# Altium schematic sheet Misc.SchDoc(Misc)
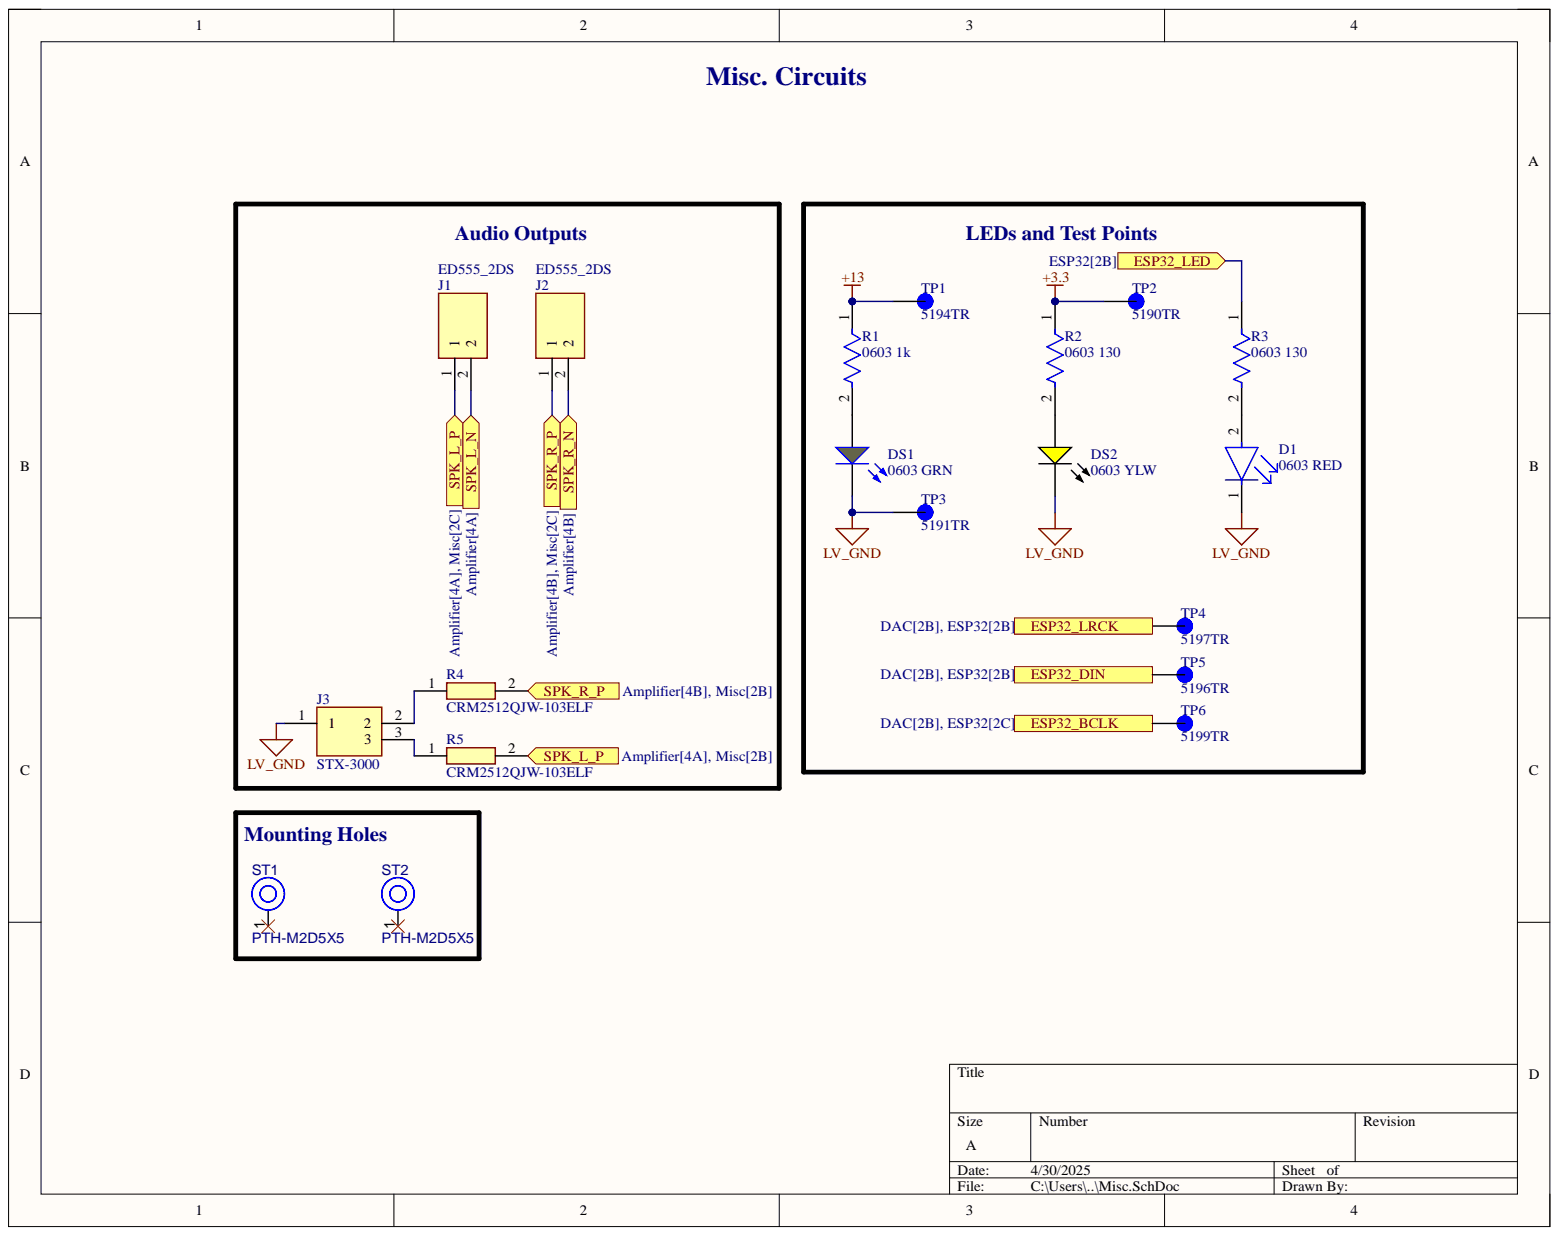
[diagram p0: Misc.SchDoc]

## Parts
D1  0603 RED  Case Code (Imperial): 0603  [FP-SML-LX0603IW-TR-MFG]
DS1  0603 GRN  [LUMX-SML-LX0603_V]
DS2  0603 YLW  [LUMX-SML-LX0603_V]
J1  ED555_2DS  [SHDR2W90P0X350_1X2_700X650X820P]
J2  ED555_2DS  [SHDR2W90P0X350_1X2_700X650X820P]
J3  STX-3000  [STX3000]
R1  0603 1k  Case Code (Imperial): 0603  [FP-CRCW0603-e3-MFG]
R2  0603 130  Case Code (Imperial): 0603  [FP-CRCW0603-e3-MFG]
R3  0603 130  Case Code (Imperial): 0603  [FP-CRCW0603-e3-MFG]
R4  CRM2512QJW-103ELF  [RESC6331X80N]
R5  CRM2512QJW-103ELF  [RESC6331X80N]
ST1  PTH-M2D5X5  [PTH-M2D5X5]
ST2  PTH-M2D5X5  [PTH-M2D5X5]
TP1  5194TR  [KEYSTONE_5194TR]
TP2  5190TR  [KEYSTONE_5190TR]
TP3  5191TR  [KEYSTONE_5191TR]
TP4  5197TR  [KEYSTONE_5197TR]
TP5  5196TR  [KEYSTONE_5196TR]
TP6  5199TR  [KEYSTONE_5199TR]

## Ports
ESP32_BCLK, ESP32_DIN, ESP32_LED, ESP32_LRCK, SPK_L_N, SPK_L_P, SPK_R_N, SPK_R_P

## Nets
+3.3: R2.1 TP2.TP
+13: R1.1 TP1.TP
LV_GND: D1.1 DS1.2 DS2.2 J3.1 TP3.TP
ESP32_BCLK: TP6.TP ; port ESP32_BCLK
ESP32_DIN: TP5.TP ; port ESP32_DIN
ESP32_LED: R3.1 ; port ESP32_LED
ESP32_LRCK: TP4.TP ; port ESP32_LRCK
SPK_L_N: J1.2 ; port SPK_L_N
SPK_L_P: J1.1 R5.2 ; port SPK_L_P
SPK_R_N: J2.2 ; port SPK_R_N
SPK_R_P: J2.1 R4.2 ; port SPK_R_P
NetD1_2: D1.2 R3.2
NetDS1_1: DS1.1 R1.2
NetDS2_1: DS2.1 R2.2
NetJ3_2: J3.2 R4.1
NetJ3_3: J3.3 R5.1

Not connected: ST1 1; ST2 1

## Library data of the parts
D1 = CMP-57192-000012-1: LED RED DIFFUSED SMD; Approvals: UL; Dominant Wavelength: 635nm; Forward Current: 20mA; Forward Voltage: 2V; Lens Color: White; Luminous Intensity: 14mcd; Number of LEDs: 1; Power Dissipation: 50mW; Viewing Angle: 160°; Case Code (Metric): 1608; Case/Package: 0603; Color: Red; Component Kind: Standard; Diameter: 0.061inch; Height: 0.6mm; Illumination Color: Red; Lead Free: Lead Free; Length: 1.6mm; Lens Style: Diffused; Lens Transparency: Diffused; Library Name: Altium Content Vault; Max Operating Temperature: 85°C; Min Operating Temperature: -25°C; Mount: Surface Mount; Number of Pins: 2; Package Quantity: 1; Packaging: Tape and Reel; RoHS Compliant: Yes; Test Current: 20mA; Wavelength: 635nm; Width: 0.8mm
DS1 = CMP-2000-07120-1: LED Green Diode, 18 mcd, 50 mW, -25 to 85 degC, 2-Pin SMD, RoHS, Tape and Reel; Manufacturer: Lumex; Part No: SML-LX0603GW-TR; COO: CN; Intensity: 18mcd; LED Material: GaP; Lens Appearance: ColorlessDiffused; Number of LEDs: 1; Peak Wavelength: 565nm; Pin_Count: 2; Reverse Voltage: 5(Min)V; Type: Uni-Color; Viewing Angle: 160; Color: Green; Component Kind: Standard; Datasheet URL: http://www.lumex.com/content/files/ProductAttachment/SML-LX0603GW-TR.pdf; Datasheet Version: Rev. G, 09/2013; Dimension: 1.6x0.8x0.6mm; Dominant Wavelength: N/A; ECCN: EAR99; Family: SML-LX0603GW; HTS: 8541402000; Lens Dimensions: 1x0.8x0.3mm; Library Name: Altium Content Vault; Manufacturer URL: http://www.lumexinstruments.com/; Mounting: SurfaceMount; Mounting Technology: SurfaceMount; Operating Temperature: -25to85C; Package Description: 2-Pin Surface Mount Device, Body 1.6 x 0.8 mm; Package Reference: SML-LX00603; Packaging: TapeandReel; RoHS: Yes; Supplier: Lumex; Supplier Standard Pack: 4,000; Supplier_Package: SMD
DS2 = CMP-2000-07121-1: Yellow LED, Milky White Diffused Lens, 2.1 V, 14 mcd, -25 to 85 degC, 2-Pin SMD, RoHS, Tape and Reel; Manufacturer: Lumex; Part No: SML-LX0603YW-TR; COO: CN; Intensity: 14mcd; LED Material: GaAsP; Lens Appearance: ColorlessDiffused; Number of LEDs: 1; Peak Wavelength: 585nm; Pin_Count: 2; Reverse Voltage: 5(Min)V; Type: Uni-Color; Viewing Angle: 160; Color: Yellow; Component Kind: Standard; Datasheet URL: http://www.lumex.com/content/files/ProductAttachment/SML-LX0603YW-TR.pdf; Datasheet Version: Rev. G, 09/2013; Dimension: 1.6x0.8x0.6mm; Dominant Wavelength: N/A; ECCN: EARII; Family: SML-LX0603YW; HTS: 8541402000; Lens Dimensions: 1x0.8x0.3mm; Library Name: Altium Content Vault; Manufacturer URL: http://www.lumexinstruments.com/; Mounting: SurfaceMount; Mounting Technology: SurfaceMount; Operating Temperature: -25to85C; Package Description: 2-Pin Surface Mount Device, Body 1.6 x 0.8 mm; Package Reference: SML-LX00603; Packaging: TapeandReel; RoHS: Unknown; Supplier: Lumex; Supplier_Package: SMD
J1 J2 = ED555_2DS: Connector; Manufacturer_Name: On Shore Technology Inc.; Manufacturer_Part_Number: ED555/2DS; Arrow Part Number: ED555/2DS; Arrow Price/Stock: https://www.arrow.com/en/products/ed5552ds/on-shore-technology?utm_currency=USD&region=nac; Component Kind: Standard; Datasheet Link: http://www.on-shore.com/wp-content/uploads/ED555XDS.pdf; Height: 8.2mm
J3 = STX-3000: Connector; Manufacturer_Name: Kycon; Component Kind: Standard; Datasheet Link: http://www.kycon.com/Pub_Eng_Draw/STX-3000.pdf; Manufacturer_Part_Number: STX-3000; Mouser Part Number: 806-STX-3000; Mouser Price/Stock: https://www.mouser.co.uk/ProductDetail/Kycon/STX-3000?qs=kjZ2mQLP346Nbz1X9BOzfg%3D%3D
R1 = CMP-1666-00003-5: RES Thick Film, 1kΩ, 1%, 0.1W, 100ppm/°C, 0603; Max Power Dissipation: 100mW; Resistance: 1kR; Voltage Rating: 75V; Case Code (Metric): 1608; Case/Package: 0603; Component Kind: Standard; Composition: Thick Film; Contact Plating: Tin; Depth: 0.85mm; Features: Automotive AEC-Q200; Height: 0.45mm; Lead Free: Lead Free; Length: 1.55mm; Library Name: Altium Content Vault; Max Operating Temperature: 155°C; Military Standard: Not; Min Operating Temperature: -55°C; Mount: Surface Mount; Number of Pins: 2; Number of Terminations: 2; Package Quantity: 10; Packaging: Tape and Reel; Power Rating: 100mW; Radiation Hardening: No; Temperature Coefficient: 100ppm/°C; Termination: SMD/SMT; Tolerance: 1%; Voltage Rating (DC): 75V; Weight: 7.1E-05oz; Width: 0.033inch
R2 R3 = CMP-2000-03889-2: RES Thick Film, 130Ω, 1%, 0.1W, 100ppm/°C, 0603; Max Power Dissipation: 100mW; Resistance: 130R; Voltage Rating: 75V; Case Code (Metric): 1608; Case/Package: 0603; Component Kind: Standard; Composition: Thick Film; Contact Plating: Tin; Depth: 0.85mm; Features: Automotive AEC-Q200; Height: 0.45mm; Lead Free: Lead Free; Length: 1.55mm; Library Name: Altium Content Vault; Max Operating Temperature: 155°C; Military Standard: Not; Min Operating Temperature: -55°C; Number of Pins: 2; Number of Terminations: 2; Package Quantity: 10; Packaging: Tape and Reel; Power Rating: 100mW; Radiation Hardening: No; Temperature Coefficient: 100ppm/°C; Termination: SMD/SMT; Tolerance: 1%; Voltage Rating (DC): 75V; Weight: 7.1E-05oz; Width: 0.85mm; Working Voltage: 75V
R4 R5 = CRM2512QJW-103ELF: Resistor; Manufacturer_Name: Bourns; Arrow Part Number: CRM2512QJW-103ELF; Arrow Price/Stock: https://www.arrow.com/en/products/crm2512qjw-103elf/bourns?region=nac; Component Kind: Standard; Datasheet Link: http://www.bourns.com/data/global/pdfs/CRM0805_1206_2010.pdf; Height: 0.8mm; Manufacturer_Part_Number: CRM2512QJW-103ELF; Mouser Part Number: 652-CRM2512QJW-103E; Mouser Price/Stock: https://www.mouser.co.uk/ProductDetail/Bourns/CRM2512QJW-103ELF?qs=8Wlm6%252BaMh8Rzg3bRHdWGsA%3D%3D
ST1 ST2 = CMP-00028-00004-2: M2 PTH (2.5mm), 5mm pad; Component Kind: Standard; Library Name: Spartan Racing Altium Workspace; Pins: 1
TP1 = CMP-137-000011-1: Color Keyed SMT Test Point -Yellow; MF: Keystone Electronics; Check_prices: https://www.snapeda.com/parts/5194TR/Keystone+Electronics/view-part/?ref=eda; Component Kind: Standard; Component Type: Standard; Design Item ID: 5194TR; Library Name: Spartan Racing Altium Workspace; MP: 5194TR; Package: None; Pin Count: 1; SnapEDA_Link: https://www.snapeda.com/parts/5194TR/Keystone+Electronics/view-part/?ref=snap; Source: 5194TR.SchLib
TP2 = CMP-137-000010-1: Color Keyed SMT Test Point -Red; MF: Keystone Electronics; Check_prices: https://www.snapeda.com/parts/5190TR/Keystone+Electronics/view-part/?ref=eda; Component Kind: Standard; Component Type: Standard; Design Item ID: 5190TR; Library Name: Spartan Racing Altium Workspace; MP: 5190TR; Package: None; Pin Count: 1; SnapEDA_Link: https://www.snapeda.com/parts/5190TR/Keystone+Electronics/view-part/?ref=snap; Source: 5190TR.SchLib
TP3 = CMP-137-000007-1: Color Keyed SMT Test Point -Black; MF: Keystone Electronics; Check_prices: https://www.snapeda.com/parts/5191TR/Keystone+Electronics/view-part/?ref=eda; Component Kind: Standard; Component Type: Standard; Design Item ID: 5191TR; Library Name: Spartan Racing Altium Workspace; MP: 5191TR; Package: None; Pin Count: 1; SnapEDA_Link: https://www.snapeda.com/parts/5191TR/Keystone+Electronics/view-part/?ref=snap; Source: 5191TR.SchLib
TP4 = CMP-137-000006-1: Color Keyed SMT Test Point - Blue; MF: Keystone Electronics; Check_prices: https://www.snapeda.com/parts/5197TR/Keystone+Electronics/view-part/?ref=eda; Component Kind: Standard; Component Type: Standard; Design Item ID: 5197TR; Library Name: Spartan Racing Altium Workspace; MP: 5197TR; Package: None; Pin Count: 1; SnapEDA_Link: https://www.snapeda.com/parts/5197TR/Keystone+Electronics/view-part/?ref=snap; Source: 5197TR.SchLib
TP5 = CMP-137-000008-1: Color Keyed SMT Test Point -Green; MF: Keystone Electronics; Check_prices: https://www.snapeda.com/parts/5196TR/Keystone+Electronics/view-part/?ref=eda; Component Kind: Standard; Component Type: Standard; Design Item ID: 5196TR; Library Name: Spartan Racing Altium Workspace; MP: 5196TR; Package: None; Pin Count: 1; SnapEDA_Link: https://www.snapeda.com/parts/5196TR/Keystone+Electronics/view-part/?ref=snap; Source: 5196TR.SchLib
TP6 = CMP-137-000009-1: Color Keyed SMT Test Point -Purple; MF: Keystone Electronics; Check_prices: https://www.snapeda.com/parts/5199TR/Keystone+Electronics/view-part/?ref=eda; Component Kind: Standard; Component Type: Standard; Design Item ID: 5199TR; Library Name: Spartan Racing Altium Workspace; MP: 5199TR; Package: None; Pin Count: 1; SnapEDA_Link: https://www.snapeda.com/parts/5199TR/Keystone+Electronics/view-part/?ref=snap; Source: 5199TR.SchLib
